# Altium schematic sheet gps.SchDoc
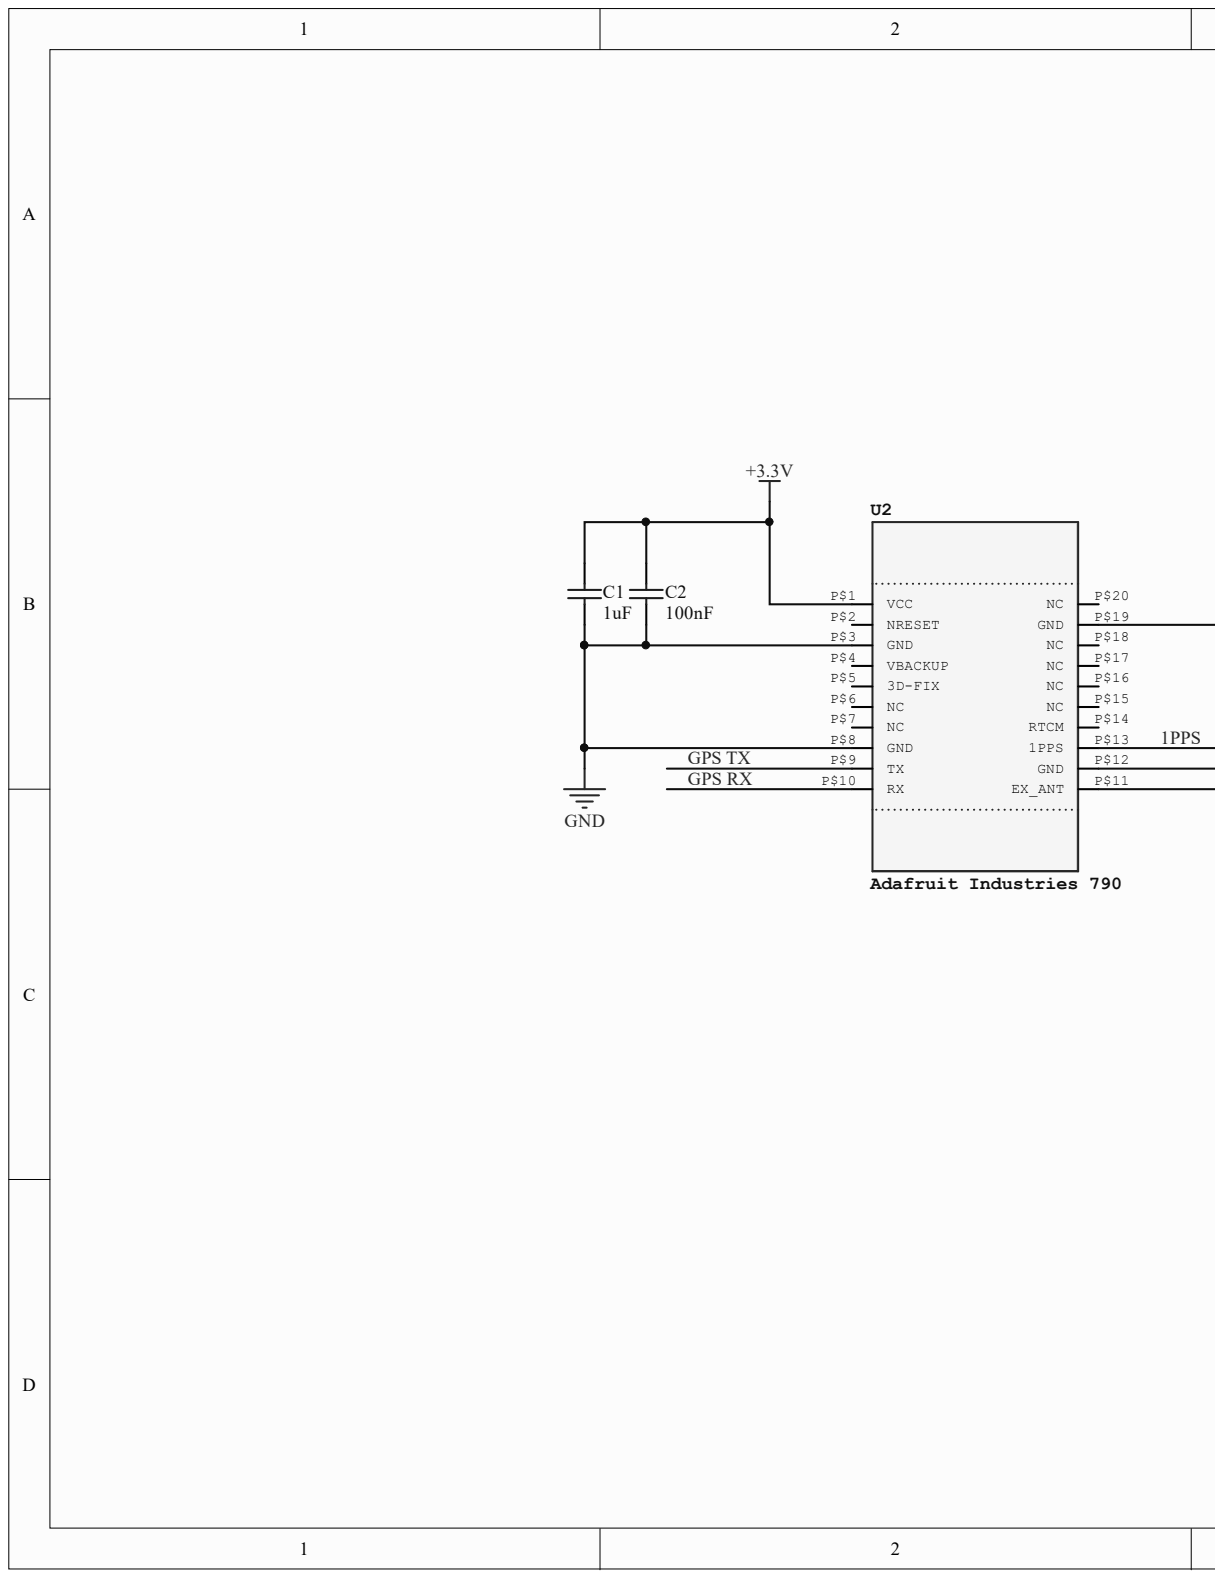
[diagram p0: gps.SchDoc, left]
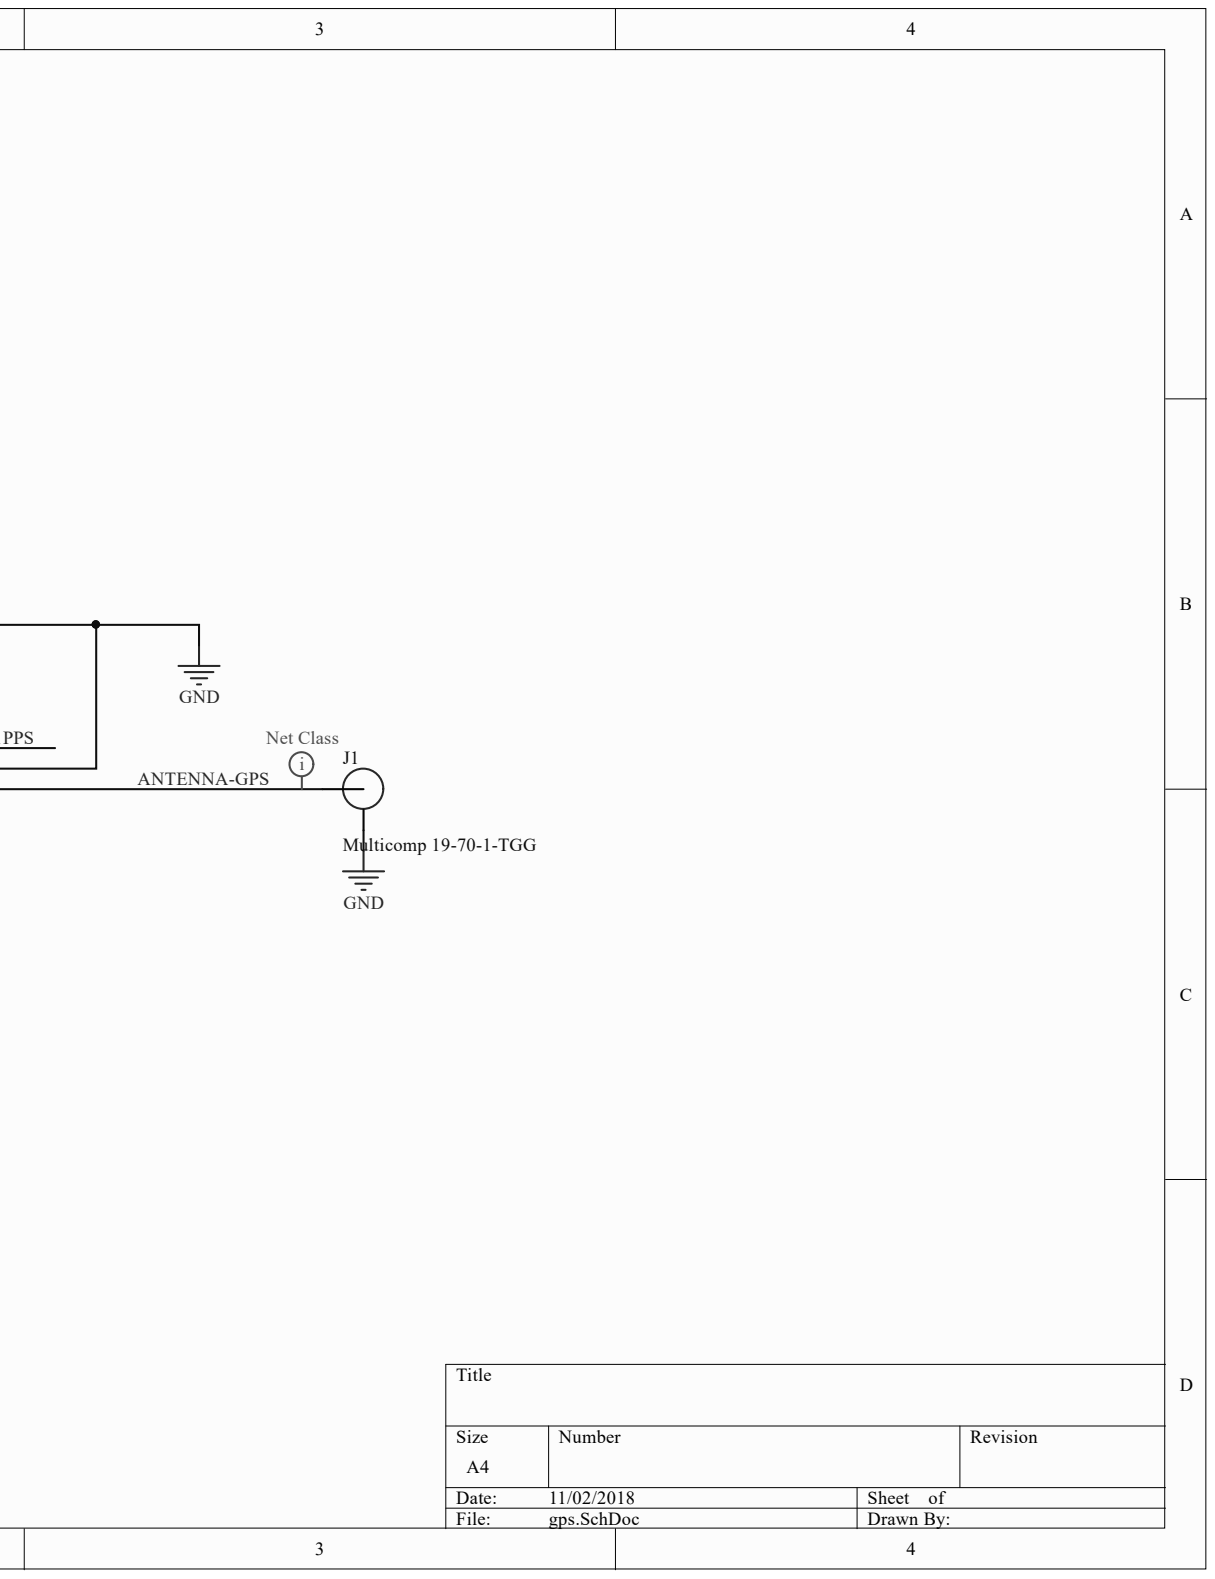
[diagram p1: gps.SchDoc, right]

## Parts
C1  1uF  [CAPC1608X90X35NL15T15]
C2  100nF  [PCB-14j6yrx10pagyq47cjuv-1]
J1  Multicomp 19-70-1-TGG  [PCB-319hzbhc3utknsftgyak-1]
U2  Adafruit Industries 790  [PCB-ai13er4fhq8b53edthv4-1]

## Nets
+3.3V: C1.2 C2.2 U2.P$1(VCC)
GND: C1.1 C2.1 J1.GND U2.P$3(GND) U2.P$8(GND) U2.P$12(GND) U2.P$19(GND)
1PPS: U2.P$13(1PPS)
ANTENNA-GPS: J1.SIG U2.P$11(EX_ANT)
GPS RX: U2.P$10(RX)
GPS TX: U2.P$9(TX)

Not connected: U2 P$2(NRESET) P$4(VBACKUP) P$5(3D-FIX) P$6(NC) P$7(NC) P$14(RTCM) P$15(NC) P$16(NC) P$17(NC) P$18(NC) P$20(NC)

## Library data of the parts
C1 = CMP-48b38119c7be9774-1: Capacitor Ceramic, 0.1uf, 50v, X7r, 10%,; Component Kind: Standard; Library Name: CommunityVault; OctopartID: 48b38119c7be9774
C2 = CMP-cab80115e1b0157f-1: 0603 1 uF 10 V ±10 % Tol. X5R Surface Mount Multilayer Ceramic Capacitor; Capacitance Tolerance: ±10%; Lead-Free Status: Lead Free; Voltage Rating (DC): 10.0 V; Case/Package: 0603; Component Kind: Standard; Dielectric Characteristic: X5R; Library Name: CommunityVault; Mounting Style: Surface Mount; OctopartID: cab80115e1b0157f; Octopart URL: http://octopart.com/0603x105k100ct-walsin+technologies-44502638; Packaging: Cut Tape (CT); RoHS: Compliant
J1 = CMP-cdb104e8e6b51276-4: SMA Connector; Connector Type: SMA Coaxial; Impedance: 50ohm; Contact Material: Beryllium Copper; Contact Plating: Go...; Insulation Resistance: 5.00 GΩ; Number of Mating Cycles: 500; Component Kind: Standard; Library Name: CommunityVault; Manufacturer Part Number: 19-70-1-TGG; Material: Brass; Mounting Style: PCB; RoHS: Compliant
U2 = CMP-f69e3e63e4362959-4: 3m Cabletie&base Assembly (1inx2in) [PK/100] 0. More details: Securing Cable And Cable Bundles To A Surface; Manufacturer Part Number: 790; Supply Voltage (DC): 48.0 V; Component Kind: Standard; Library Name: CommunityVault; OctopartID: f69e3e63e4362959; Octopart URL: http://octopart.com/790-adafruit+industries-32978894; REACH SVHC Compliance: No SVHC; RoHS: Non-Compliant
